# Altium schematic sheet 4_SD_Card.SchDoc
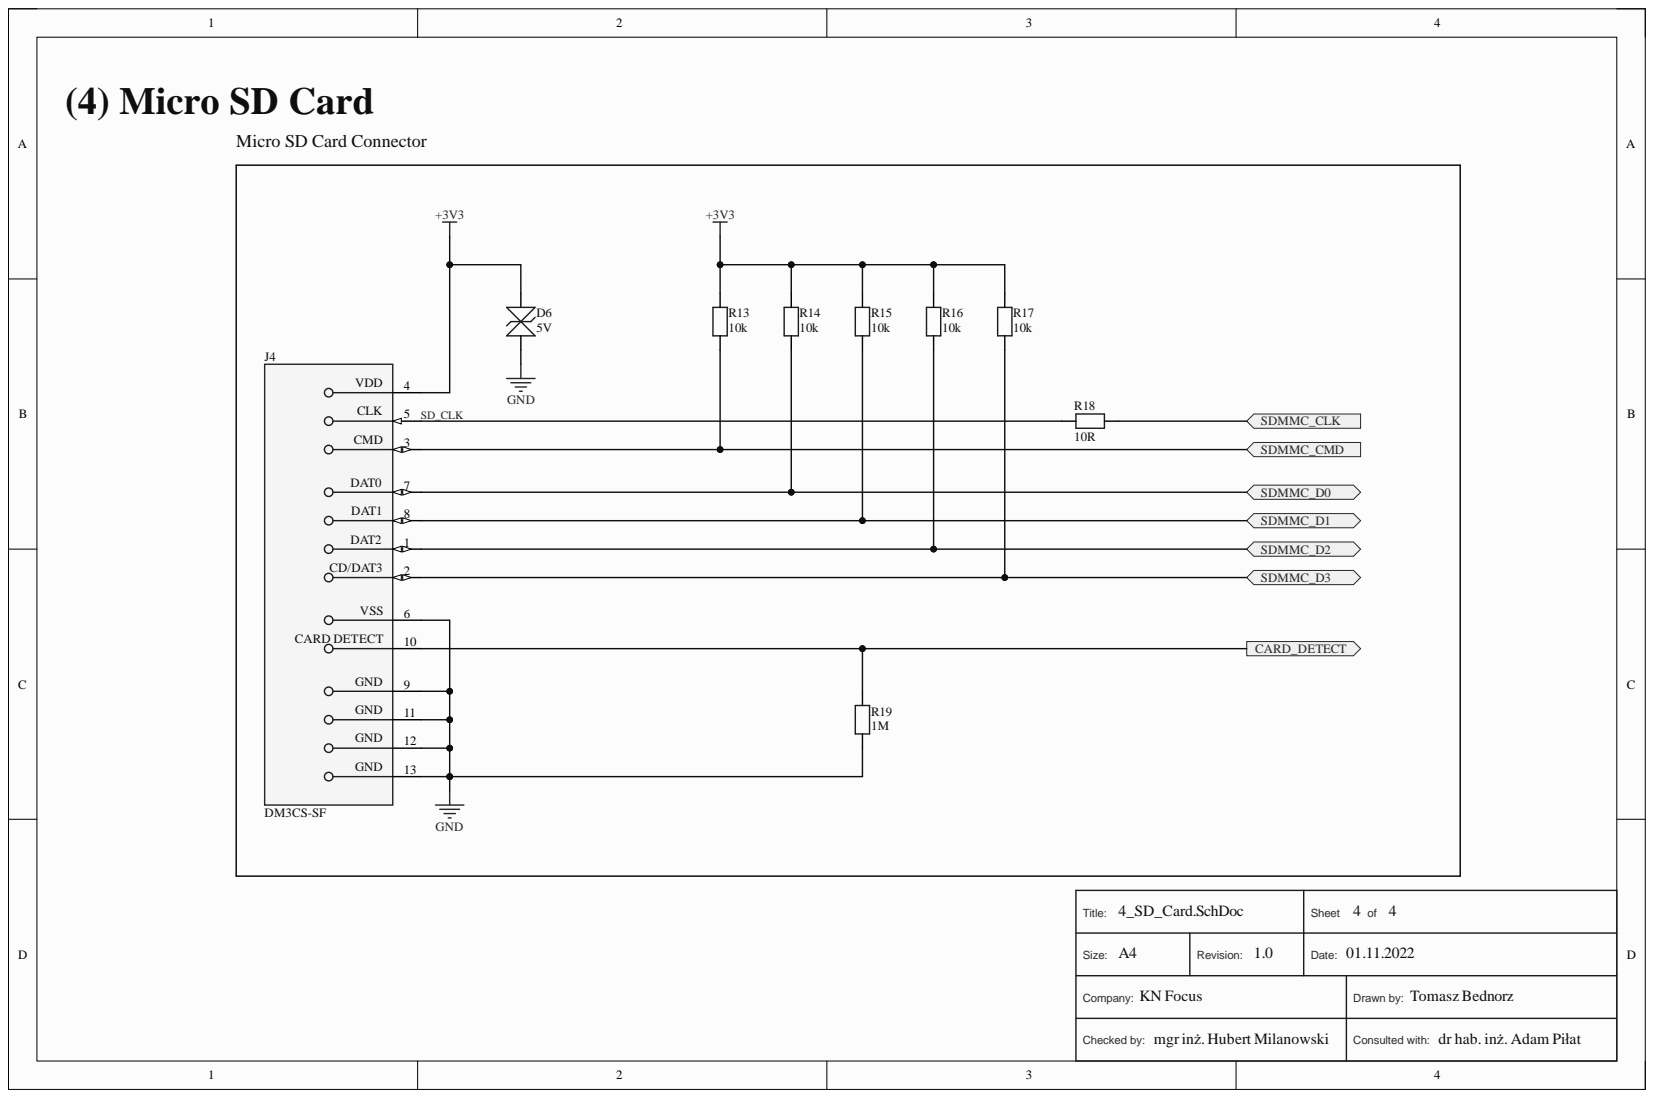
[diagram p0: 4_SD_Card.SchDoc]

## Parts
D6  5V  [TVS_Littlefuse]
J4  DM3CS-SF  [FP-DM3CS-SF-MFG]
R13  10k  [RES_1206]
R14  10k  [RES_1206]
R15  10k  [RES_1206]
R16  10k  [RES_1206]
R17  10k  [RES_1206]
R18  10R  [RES_1206]
R19  1M  [RES_1206]

## Ports
CARD_DETECT, SDMMC_CLK, SDMMC_CMD, SDMMC_D0, SDMMC_D1, SDMMC_D2, SDMMC_D3

## Nets
+3V3: D6.1 J4.4 R13.2 R14.2 R15.2 R16.2 R17.2
GND: D6.2 J4.6 J4.9 J4.11 J4.12 J4.13 R19.2
CARD_DETECT: J4.10 R19.1 ; port CARD_DETECT
SD_CLK: J4.5 R18.2
SDMMC_CLK: R18.1 ; port SDMMC_CLK
SDMMC_CMD: J4.3 R13.1 ; port SDMMC_CMD
SDMMC_D0: J4.7 R14.1 ; port SDMMC_D0
SDMMC_D1: J4.8 R15.1 ; port SDMMC_D1
SDMMC_D2: J4.1 R16.1 ; port SDMMC_D2
SDMMC_D3: J4.2 R17.1 ; port SDMMC_D3

## Library data of the parts
D6 = TVS_5V: TVS Diode TVS_SMAJ5.0CA  5V Bidirectional; Component Kind: Standard; Library Name: SymbolLib.SchLib
J4 = CMP-11597-000003-1: CONN MICRO SD CARD HINGED TYPE; Connector Type: Connector; Contact Resistance: 100mR; Current Rating: 500mA; Gender: Female; Insulation Resistance: 1GR; Number of Contacts: 8; Pitch: 1.1mm; Voltage Rating (AC): 125V; Component Kind: Standard; Contact Material: Gold; Contact Plating: Gold; Depth: 1.83mm; Flammability Rating: UL94 V-0; Housing Material: Polymer; Lead Free: Lead Free; Length: 14.1mm; Library Name: Altium Content Vault; Max Current Rating: 500mA; Max Operating Temperature: 85°C; Min Operating Temperature: -25°C; Mount: Surface Mount; Number of Positions: 8; Number of Rows: 1; Orientation: Right Angle; Package Quantity: 1; Packaging: Tape and Reel; Radiation Hardening: No; Termination: Solder; Width: 13.8mm
R13-R19 = RES_1206: Generic 1206/3216 resistor; Component Kind: Standard; Library Name: SymbolLib.SchLib
